# Altium schematic sheet iRTT-AU.SchDoc(iRTT-AU)
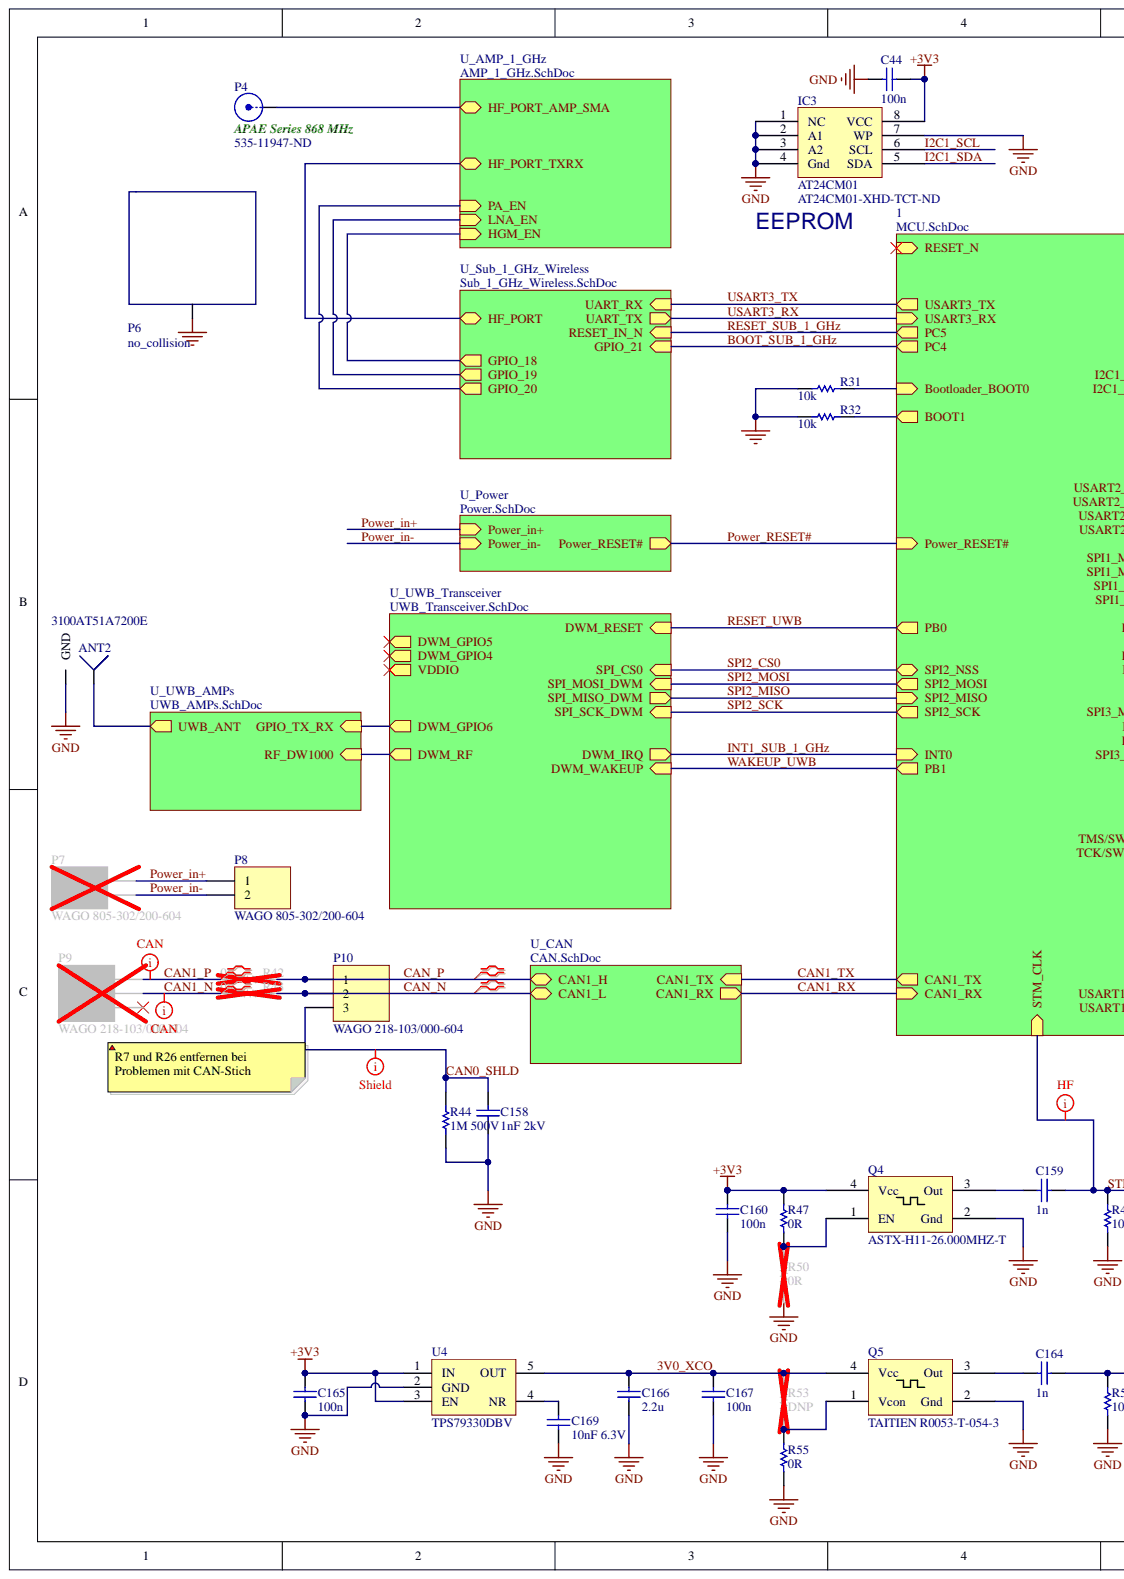
[diagram p0: iRTT-AU.SchDoc, left]
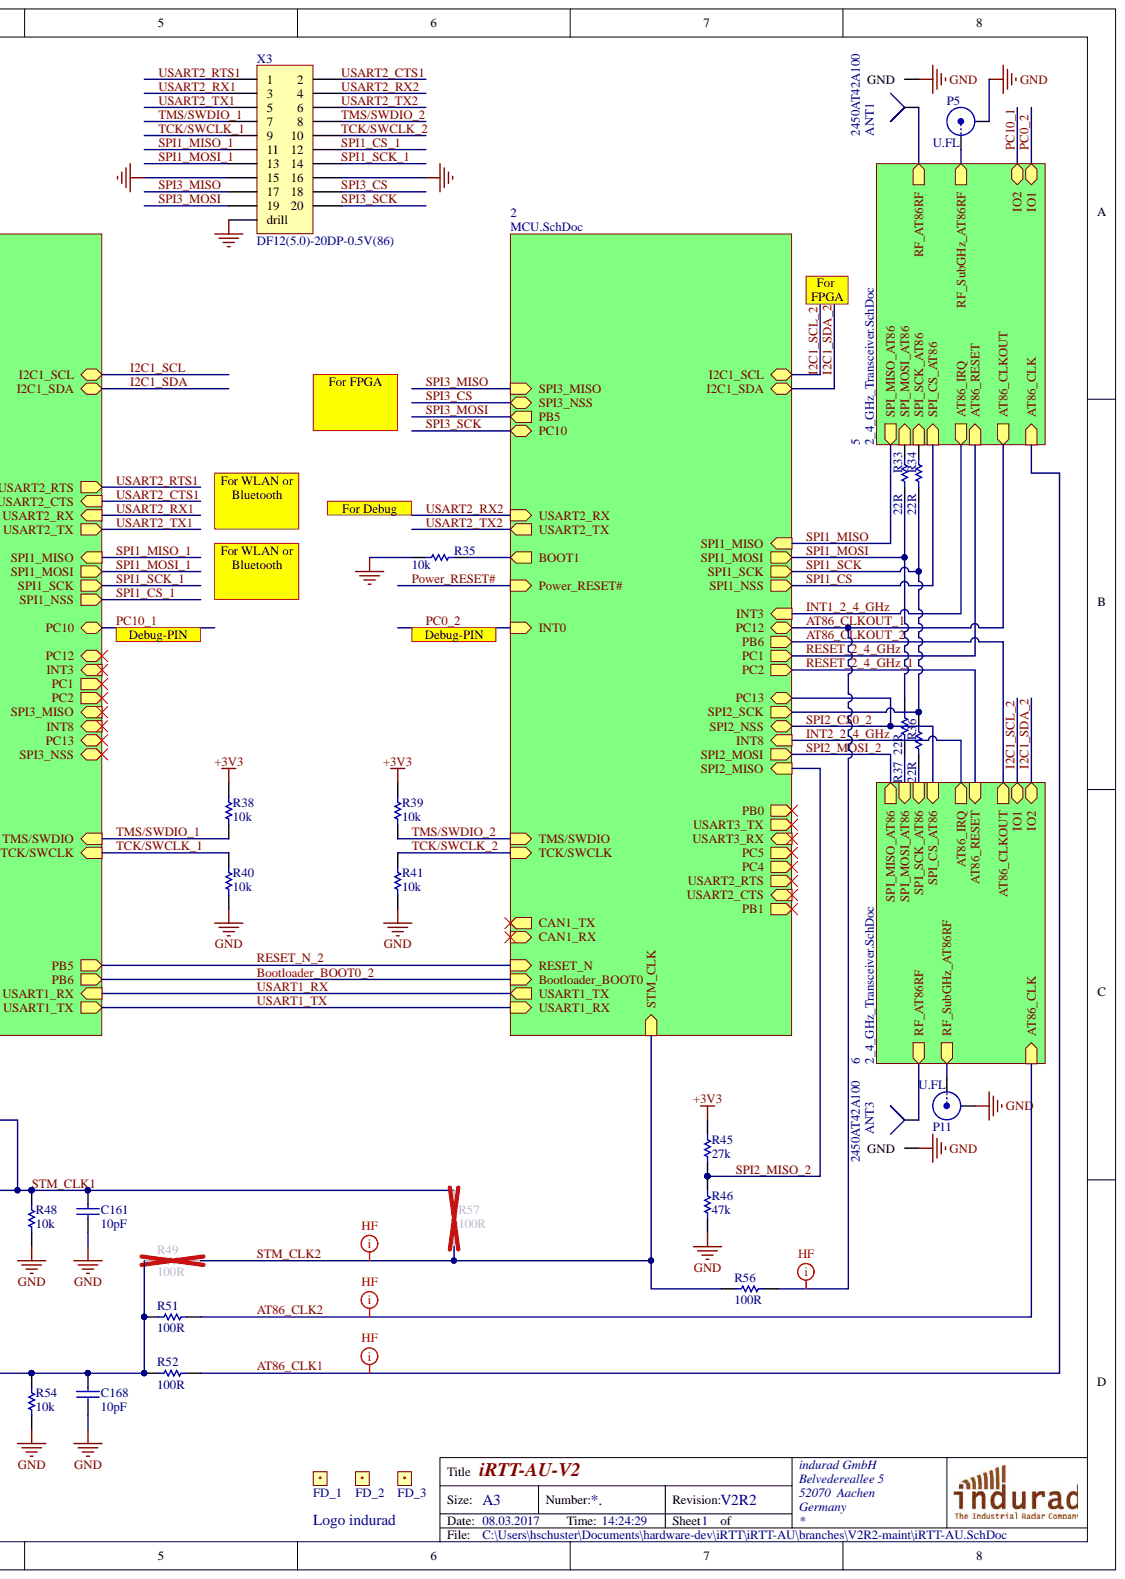
[diagram p1: iRTT-AU.SchDoc, right]

## Parts
ANT1  2450AT42A100  [2.4GHz_Antenna_2450AT42A100]
ANT2  3100AT51A7200E  [UWB_Antenne_3100AT51A7200E]
ANT3  2450AT42A100  [2.4GHz_Antenna_2450AT42A100]
C44  100n  [0402_N_(1005)]
C158  1nF 2kV  [CAPC_1206_N_(3216)]
C159  1n  [0402_N_(1005)]
C160  100n  [0402_N_(1005)]
C161  10pF  [0402_N_(1005)]
C164  1n  [0402_N_(1005)]
C165  100n  [0402_N_(1005)]
C166  2.2u  [0805_N_(2012)]
C167  100n  [0402_N_(1005)]
C168  10pF  [0402_N_(1005)]
C169  10nF 6.3V  Voltage - Rated: 6.3V  [0201_N_(0603)]
FD_1  Fiducial  [BestueckerMarke_ohne_TopOverlay]  (value not drawn)  (no BOM)
FD_2  Fiducial  [BestueckerMarke_ohne_TopOverlay]  (value not drawn)  (no BOM)
FD_3  Fiducial  [BestueckerMarke_ohne_TopOverlay]  (value not drawn)  (no BOM)
IC3  AT24CM01  Supplier Part Number 1: AT24CM01-XHD-TCT-ND  [TSSOP8]
logo1  Logo_indurad  [LOGO_INDURAD_H_3mm]  (no BOM)
P4  APAE Series 868 MHz  Supplier Part Number 1: 535-11947-ND  [APAE Series Abracon]
P5  U.FL  [U.FL Kupplung]
P6  ClassName: no_collision  [SMD Shielding Cabinet 29,96 X 19,10 mm]  (hidden comment: SMD Shield 29,36x18,50x2,54x0,20)
P7  WAGO 805-302/200-604  [WAGO 805-302/200-604]
P8  WAGO 805-302/200-604  [WAGO 805-302/200-604]
P9  WAGO 218-103/000-604  [WAGO 218-103/000-604]
P10  WAGO 218-103/000-604  [WAGO 218-103/000-604]
P11  U.FL  [U.FL Kupplung]
Q4  ASTX-H11-26.000MHZ-T  [Osz-3.2x2.5]
Q5  TAITIEN R0053-T-054-3  [Osz-3.2x2.5]
R31  10k  [0603_N_(1608)]
R32  10k  [0603_N_(1608)]
R33  22R  [0402_N_(1005)]
R34  22R  [0402_N_(1005)]
R35  10k  [0603_N_(1608)]
R36  22R  [0402_N_(1005)]
R37  22R  [0402_N_(1005)]
R38  10k  [0402_N_(1005)]
R39  10k  [0402_N_(1005)]
R40  10k  [0402_N_(1005)]
R41  10k  [0402_N_(1005)]
R42  0R  [0603_N_(1608)]
R43  0R  [0603_N_(1608)]
R44  1M 500V  [1210]
R45  27k  [0603_N_(1608)]
R46  47k  [0603_N_(1608)]
R47  0R  [0402_N_(1005)]
R48  10k  [0402_N_(1005)]
R49  100R  [0402_N_(1005)]
R50  0R  [0402_N_(1005)]
R51  100R  [0402_N_(1005)]
R52  100R  [0402_N_(1005)]
R53  DNP  [0402_N_(1005)]
R54  10k  [0402_N_(1005)]
R55  0R  [0402_N_(1005)]
R56  100R  [0402_N_(1005)]
R57  100R  [0402_N_(1005)]
U4  TPS79330DBV  [SOT23-5]
X3  DF12(5.0)-20DP-0.5V(86)  [Hirose DF12(5.0)-20DP-0.5V(86)]

## Nets
+3V3: C44.2 C160.2 C165.2 IC3.8(VCC) Q4.4(Vcc) R38.1 R39.1 R45.1 R47.2 U4.1(IN) U4.3(EN)
GND: ANT1.2 ANT2.2 ANT3.2 C44.1 C158.2 C160.1 C161.1 C165.1 C166.1 C167.1 C168.1 C169.1 IC3.1(NC) IC3.2(A1) IC3.3(A2) IC3.4(Gnd) IC3.7(WP) P5.2 P6.1 P11.2 Q4.2(Gnd) Q5.2(Gnd) R31.2 R32.2 R35.2 R40.2 R41.2 R44.1 R46.2 R48.2 R50.1 R54.2 R55.1 U4.2(GND) X3.15 X3.16 X3.21(drill)
3V0_XCO: C166.2 C167.2 Q5.4(Vcc) R53.2 U4.5(OUT)
AT86_CLK1: R52.1
AT86_CLK2: R51.1
AT86_CLKOUT_1: R56.1
CAN0_SHLD: C158.1 P10.3 R44.2
CAN1_N: P9.2 R43.2
CAN1_P: P9.3 R42.2
CAN_N: P10.2 R43.1
CAN_P: P10.1 R42.1
I2C1_SCL: IC3.6(SCL)
I2C1_SDA: IC3.5(SDA)
Power_in+: P7.2 P8.1
Power_in-: P7.1 P8.2
SPI1_CS_1: X3.12
SPI1_MISO_1: X3.11
SPI1_MOSI: R33.1 R37.2
SPI1_MOSI_1: X3.13
SPI1_SCK: R34.1 R36.2
SPI1_SCK_1: X3.14
SPI2_MISO_2: R45.2 R46.1
SPI3_CS: X3.18
SPI3_MISO: X3.17
SPI3_MOSI: X3.19
SPI3_SCK: X3.20
STM_CLK1: C159.2 C161.2 R48.1 R57.1
STM_CLK2: R49.1 R56.2 R57.2
TCK/SWCLK_1: R40.1 X3.9
TCK/SWCLK_2: R41.1 X3.10
TMS/SWDIO_1: R38.2 X3.7
TMS/SWDIO_2: R39.2 X3.8
USART2_CTS1: X3.2
USART2_RTS1: X3.1
USART2_RX1: X3.3
USART2_RX2: X3.4
USART2_TX1: X3.5
USART2_TX2: X3.6
NetANT1_1: ANT1.1
NetANT2_1: ANT2.1
NetANT3_1: ANT3.1
NetC159_1: C159.1 Q4.3(Out)
NetC164_1: C164.1 Q5.3(Out)
NetC164_2: C164.2 C168.2 R49.2 R51.2 R52.2 R54.1
NetC169_2: C169.2 U4.4(NR)
NetP4_1: P4.1
NetP5_1: P5.1
NetP9_1: P9.1
NetP11_1: P11.1
NetQ4_1: Q4.1(EN) R47.1 R50.2
NetQ5_1: Q5.1(Vcon) R53.1 R55.2
NetR31_1: R31.1
NetR32_1: R32.1
NetR33_2: R33.2
NetR34_2: R34.2
NetR35_1: R35.1
NetR36_1: R36.1
NetR37_1: R37.1
AT86_CLKOUT_2:
BOOT_SUB_1_GHz:
Bootloader_BOOT0_2:
CAN1_RX:
CAN1_TX:
I2C1_SCL_2:
I2C1_SDA_2:
INT1_2_4_GHz:
INT1_SUB_1_GHz:
INT2_2_4_GHz:
N00141:
N00142:
N00143:
N00144:
N00145:
N00146:
N00147:
N00148:
N00149:
N00150:
N00151:
N00152:
N00153:
N00154:
N00155:
N00156:
N00157:
N00158:
N00159:
N00160:
N00161:
N00162:
N00163:
N00164:
N00165:
N00166:
N00167:
N00168:
PC0_2:
PC10_1:
Power_RESET#:
RESET_2_4_GHz:
RESET_2_4_GHz_1:
RESET_N_2:
RESET_SUB_1_GHz:
RESET_UWB:
SPI1_CS:
SPI1_MISO:
SPI2_CS0:
SPI2_CS0_2:
SPI2_MISO:
SPI2_MOSI:
SPI2_MOSI_2:
SPI2_SCK:
USART1_RX:
USART1_TX:
USART3_RX:
USART3_TX:
WAKEUP_UWB:

## Library data of the parts
ANT1 ANT3 = 2.4 GHz Antenna: ANTENNA CHIP 2.4 - 2.5 GHZ; Manufacturer Part Number: 2450AT42A100E; Manufacturer: Johanson Technology Inc.; Return Loss: -9.5dB; Power - Max: 3W; Number of Bands: 1; Gain: 0dBi; Frequency Range: 2.4GHz ~ 2.5GHz; Frequency Group: UHF (2 GHz ~ 3 GHz); Frequency (Center/Band): 2.4GHz; VSWR: -; Termination: Solder; Supplier Part Number 1: 712-1007-1-ND; Supplier 1: Digi-Key; Stock 1: 32278; RoHS: RoHS Compliant; Pricing 1: 1=0.72, 10=0.633, 25=0.5604, 100=0.4881, 250=0.42484, 500=0.36158, 1000=0.28926 (EUR); Part Status: Active; Packaging: Cut Tape (CT); Mounting Type: Surface Mount; Ingress Protection: -; Height (Max): 0.043" (1.10mm); Features: -; ComponentLink1URL: http://www.johansontechnology.com/datasheets/antennas/2450AT42A100_v2.pdf; ComponentLink1Description: http://www.johansontechnology.com/datasheets/antennas/2450AT42A100_v2.pdf; Component Kind: Standard; Category: RF/IF and RFID; Applications: -; Antenna Type: Chip; Library Name: Indurad.SchLib
ANT2 = UWB Antenna: ANTENNA CHIP UWB 3.1 - 10.3 GHZ; Manufacturer: Johanson Technology Inc.; Return Loss: -9.5dB; Power - Max: 3W; Number of Bands: 1; Gain: 1.5dBi; Frequency Range: 3.1GHz ~ 10.3GHz; Frequency Group: SHF (3 GHz ~ 4 GHz), SHF (f > 4 GHz); Frequency (Center/Band): 6.7GHz; VSWR: -; Termination: Solder; Supplier Part Number 1: 712-1402-1-ND; Supplier 1: Digi-Key; Stock 1: 2506; RoHS: RoHS Compliant; Pricing 1: 1=2.49, 10=2.065, 25=1.9744, 50=1.9136, 100=1.7313, 250=1.4276, 500=1.2757 (EUR); Mounting Type: Surface Mount; Manufacturer Part Number: 3100AT51A7200E; Ingress Protection: -; Height (Max): 0.047" (1.20mm); Features: -; ComponentLink1URL: http://www.johansontechnology.com/datasheets/antennas/antenna_3100AT51A7200.pdf; ComponentLink1Description: http://www.johansontechnology.com/datasheets/antennas/antenna_3100AT51A7200.pdf; Component Kind: Standard; Category: RF/IF and RFID; Applications: -; Antenna Type: Chip; Library Name: Indurad.SchLib
C44 = CMP-1034-02072-1: CAP 100nF 25V ±10% 0402 (1005 Metric) Thickness 0.6mm SMD; Case-Metric: 1005; Case-EIA: 0402; Value: 100nF; Tolerance: ±10%; Technology: SMT; Rated Voltage: 25 V; Max Thickness: 0.6 mm; Library Name: Altium Vault; Component Kind: Standard
C158 = Cap: Capacitor; Value: 1nF 2kV; Simulation: CAP; Signal Integrity: Cap; Published: 8-Jun-2000; LatestRevisionNote: Aufgenommen; LatestRevisionDate: 18.10.2012; Component Kind: Standard; Publisher: Altium Limited; Library Name: Indurad.SchLib
C159 C164 = Cap: Capacitor; Manufacturer Part Number: GRM155R71H102KA01D; Manufacturer: Murata Electronics North America; Voltage - Rated: 50V; Tolerance: ±10%; Temperature Coefficient: X7R; Capacitance: 1000pF; Value: 1n; Thickness (Max): 0.022" (0.55mm); Supplier Part Number 1: 490-1303-1-ND; Supplier 1: Digi-Key; Stock 1: 5002515; Size / Dimension: 0.039" L x 0.020" W (1.00mm x 0.50mm); Simulation: CAP; Signal Integrity: Cap; RoHS: RoHS Compliant; Ratings: -; Pricing 1: 1=0.16, 10=0.016, 50=0.0094, 100=0.0078, 250=0.00636, 500=0.00544, 1000=0.00422, 5000=0.00353 (EUR); Part Status: Active; Packaging: Cut Tape (CT); Package / Case: 0402 (1005 Metric); Operating Temperature: -55°C ~ 125°C; Mounting Type: Surface Mount, MLCC; Lead Style: -; Lead Spacing: -; LatestRevisionNote: Aufgenommen; LatestRevisionDate: 20130712; Height - Seated (Max): -; Features: -; ComponentLink1URL: http://www.murata.com/~/media/webrenewal/support/library/catalog/products/capacitor/mlcc/c02e.pdf; ComponentLink1Description: http://www.murata.com/~/media/webrenewal/support/library/catalog/products/capacitor/mlcc/c02e.pdf; Component Kind: Standard; Category: Capacitors; Applications: General Purpose; Publisher: Indurad; Library Name: Indurad.SchLib
C160 C165 C167 = Cap: Capacitor; Manufacturer Part Number: GRM155R70J104KA01D; Manufacturer: Murata Electronics North America; Voltage - Rated: 6.3V; Tolerance: ±10%; Temperature Coefficient: X7R; Capacitance: 0.1µF; Value: 100n; Thickness (Max): 0.022" (0.55mm); Supplier Part Number 1: 490-6319-1-ND; Supplier 1: Digi-Key; Stock 1: 430852; Size / Dimension: 0.039" L x 0.020" W (1.00mm x 0.50mm); Simulation: CAP; Signal Integrity: Cap; RoHS: RoHS Compliant; Ratings: -; Pricing 1: 1=0.1, 10=0.025, 50=0.0136, 100=0.0114, 250=0.00936, 500=0.008, 1000=0.00622, 5000=0.00519 (EUR); Packaging: Cut Tape (CT); Package / Case: 0402 (1005 Metric); Operating Temperature: -55°C ~ 125°C; Mounting Type: Surface Mount, MLCC; Lead Spacing: -; LatestRevisionNote: Aufgenommen; LatestRevisionDate: 20130712; Height - Seated (Max): -; Features: -; ComponentLink1URL: http://www.murata.com/~/media/webrenewal/support/library/catalog/products/capacitor/mlcc/c02e.ashx; ComponentLink1Description: http://www.murata.com/~/media/webrenewal/support/library/catalog/products/capacitor/mlcc/c02e.ashx; Component Kind: Standard; Category: Capacitors; Applications: General Purpose; Publisher: Indurad; Library Name: Indurad.SchLib
C161 C168 = Cap: Capacitor; Manufacturer Part Number: GRM1555C1H120JA01D; Manufacturer: Murata Electronics North America; Voltage - Rated: 50V; Tolerance: ±5%; Temperature Coefficient: C0G, NP0; Capacitance: 12pF; Value: 10pF; Thickness (Max): 0.022" (0.55mm); Supplier Part Number 1: 490-5924-1-ND; Supplier 1: Digi-Key; Stock 1: 400625; Size / Dimension: 0.039" L x 0.020" W (1.00mm x 0.50mm); Simulation: CAP; Signal Integrity: Cap; RoHS: RoHS Compliant; Ratings: -; Pricing 1: 1=0.14, 10=0.019, 50=0.0106, 100=0.0091, 250=0.0074, 500=0.00636, 1000=0.00494, 5000=0.00412 (EUR); Packaging: Cut Tape (CT); Package / Case: 0402 (1005 Metric); Operating Temperature: -55°C ~ 125°C; Mounting Type: Surface Mount, MLCC; Lead Style: -; Lead Spacing: -; LatestRevisionNote: Aufgenommen; LatestRevisionDate: 20130712; Height - Seated (Max): -; Features: -; ComponentLink1URL: http://www.murata.com/~/media/webrenewal/support/library/catalog/products/capacitor/mlcc/c02e.pdf; ComponentLink1Description: http://www.murata.com/~/media/webrenewal/support/library/catalog/products/capacitor/mlcc/c02e.pdf; Component Kind: Standard; Category: Capacitors; Applications: General Purpose; Publisher: Indurad; Library Name: Indurad.SchLib
C166 = Cap: Capacitor; Manufacturer Part Number: C2012X7R1C225K125AB; Manufacturer: TDK Corporation; Voltage - Rated: 16V; Tolerance: ±10%; Temperature Coefficient: X7R; Capacitance: 2.2µF; Value: 2.2u; Thickness (Max): 0.057" (1.45mm); Supplier Part Number 1: 445-1420-1-ND; Supplier 1: Digi-Key; Stock 1: 164691; Size / Dimension: 0.079" L x 0.049" W (2.00mm x 1.25mm); Simulation: CAP; Signal Integrity: Cap; RoHS: RoHS Compliant; Ratings: -; Pricing 1: 1=0.17, 10=0.119, 100=0.0604, 250=0.04892, 500=0.04318, 1000=0.0367 (EUR); Part Status: Active; Packaging: Cut Tape (CT); Package / Case: 0805 (2012 Metric); Operating Temperature: -55°C ~ 125°C; Mounting Type: Surface Mount, MLCC; Lead Style: -; Lead Spacing: -; LatestRevisionNote: Aufgenommen; LatestRevisionDate: 20130712; Height - Seated (Max): -; Features: Low ESL; Failure Rate: -; ComponentLink1URL: https://product.tdk.com/info/en/catalog/datasheets/mlcc_commercial_general_en.pdf; ComponentLink1Description: https://product.tdk.com/info/en/catalog/datasheets/mlcc_commercial_general_en.pdf; Component Kind: Standard; Category: Capacitors; Applications: General Purpose; Publisher: Indurad; Library Name: Indurad.SchLib
C169 = Cap: Capacitor; Manufacturer Part Number: GRM033R70J103KA01D; Manufacturer: Murata Electronics North America; Tolerance: ±10%; Temperature Coefficient: X7R; Capacitance: 10000pF; Value: 10nF 6.3V; Thickness (Max): 0.013" (0.33mm); Supplier Part Number 1: 490-1262-1-ND; Supplier 1: Digi-Key; Stock 1: 506964; Size / Dimension: 0.024" L x 0.012" W (0.60mm x 0.30mm); Simulation: CAP; Signal Integrity: Cap; RoHS: RoHS Compliant; Ratings: -; Pricing 1: 1=0.09, 10=0.021, 50=0.0112, 100=0.0095, 250=0.00772, 500=0.0066, 1000=0.00514, 5000=0.00428 (EUR); Packaging: Cut Tape (CT); Package / Case: 0201 (0603 Metric); Operating Temperature: -55°C ~ 125°C; Mounting Type: Surface Mount, MLCC; Lead Style: -; Lead Spacing: -; LatestRevisionNote: Aufgenommen; LatestRevisionDate: 20130712; Height - Seated (Max): -; Features: -; ComponentLink1URL: http://www.murata.com/~/media/webrenewal/support/library/catalog/products/capacitor/mlcc/c02e.pdf; ComponentLink1Description: http://www.murata.com/~/media/webrenewal/support/library/catalog/products/capacitor/mlcc/c02e.pdf; Component Kind: Standard; Category: Capacitors; Applications: General Purpose; Publisher: Indurad; Library Name: Indurad.SchLib
IC3 = AT24CM01: EEPROM 1024k 2,5 V bis 5,5 V 400kBit/s / 1MBit/s; Manufacturer Part Number: AT24CM01-XHD-T; Manufacturer: Atmel; Voltage - Supply: 2.5 V ~ 5.5 V; Speed: 1MHz; Memory Size: 1M (128K x 8); Interface: I²C, 2-Wire Serial; Format - Memory: EEPROMs - Serial; Supplier Device Package: 8-TSSOP; Supplier 1: Digi-Key; Stock 1: 6550; RoHS: RoHS Compliant; Pricing 1: 1=2.94, 25=2.4764, 100=2.0077, 1000=1.84707 (EUR); Packaging: Cut Tape (CT); Package / Case: 8-TSSOP (0.173", 4.40mm Width); Operating Temperature: -40°C ~ 85°C; Memory Type: EEPROM; ComponentLink1URL: http://www.atmel.com/Images/Atmel-8812-SEEPROM-AT24CM01-Datasheet.pdf; ComponentLink1Description: http://www.atmel.com/Images/Atmel-8812-SEEPROM-AT24CM01-Datasheet.pdf; Component Kind: Standard; Category: Integrated Circuits (ICs); Library Name: Indurad.SchLib
logo1 = Logo_indurad: Logo von indurad GmbH; Library Name: Indurad.SchLib
P4 = APAE Series: 24 X 24 mm Ceramic Antenna; Manufacturer Part Number: APAE868R2540JBDB2-T; Manufacturer: Abracon LLC; VSWR: 1.5; Number of Bands: 1; Gain: 4.5dBic; Frequency Range: 867MHz ~ 869MHz; Frequency Group: UHF (300 MHz ~ 1 GHz); Frequency (Center/Band): 868MHz; Antenna Type: Ceramic Patch; Termination: Pin; Supplier 1: Digi-Key; Stock 1: 636; RoHS: RoHS Compliant; Return Loss: -; Pricing 1: 1=2.64, 10=2.356, 25=2.1208, 100=1.932, 250=1.74356, 500=1.56448, 750=1.41368, 1000=1.31944 (EUR); Power - Max: -; Mounting Type: Adhesive; Ingress Protection: -; Height (Max): 0.157" (4.00mm); Features: -; ComponentLink1URL: http://www.abracon.com/Support/PackageDrawing/patch-antenna/APAE868R2540JBDB2-T.PDF; ComponentLink1Description: http://www.abracon.com/Support/PackageDrawing/patch-antenna/APAE868R2540JBDB2-T.PDF; Component Kind: Standard; Category: RF/IF and RFID; Applications: GPS; Library Name: Indurad.SchLib
P5 P11 = U.FL KUPPLUNG: Manufacturer Part Number: U.FL-R-SMT-1(10); Manufacturer: HRS (HIROSE); Supplier Part Number 1: 1688077; Supplier 1: Farnell; Stock 1: 104388; RoHS: YES; Pricing 1: 1=0,481, 10=0,436, 25=0,407, 50=0,365, 100=0,33 (EUR); LatestRevisionNote: Re-released for DXP Platform.; LatestRevisionDate: 17-Jul-2002; Description: U.FL KUPPLUNG; ComponentLink1URL: http://www.farnell.com/datasheets/91524.pdf; ComponentLink1Description: http://www.farnell.com/datasheets/91524.pdf; Component Kind: Standard; Publisher: Altium Limited; Library Name: Indurad.SchLib
P6 = SMD Shield 29,36x18,50x2,54x0,20: SMD Shielding Cabinet SGH-29,36x18,50x2,54x0,20; Manufacturer Part Number: SGH-207953-F / SGH-29,96x19,10x2,00x0,15-FS-C; Manufacturer: MTC; Supplier Part Number 1: SGH-207953-F / SGH-29,96x19,10x2,00x0,15-FS-C; Supplier 1: MTC; Component Kind: Standard; Library Name: Indurad.SchLib
P7 P8 = WAGO 805-302/200-604: Header, 2-Pin, single row; Signal Integrity: Connector; Component Kind: Standard; Library Name: Indurad.SchLib
P9 P10 = WAGO 218-103/000-604: Header, 3-Pin, single row; Signal Integrity: Connector; Component Kind: Standard; Library Name: Indurad.SchLib
Q4 = ASTX-H11-26.000MHZ-T: OSC TCXO 26.000MHZ HCMOS SMD; Manufacturer: Abracon LLC; Voltage - Supply: 3.3V; Function: Enable/Disable; Frequency Stability: ±2.5ppm; Frequency: 26MHz; Current - Supply (Max): 5.5mA; Type: TCXO; Supplier Part Number 1: 535-12047-1-ND; Supplier 1: Digi-Key; Stock 1: 865; Size / Dimension: 0.126" L x 0.098" W (3.20mm x 2.50mm); RoHS: RoHS Compliant; Ratings: -; Pricing 1: 1=3.19, 10=3.04, 50=2.8878, 100=2.5838, 500=2.43184 (EUR); Part Status: Active; Packaging: Cut Tape (CT); Package / Case: 4-SMD, No Lead; Output: HCMOS; Operating Temperature: -30°C ~ 75°C; Mounting Type: Surface Mount; Manufacturer Part Number: ASTX-H11-26.000MHZ-T; Height - Seated (Max): 0.043" (1.10mm); Current - Supply (Disable) (Max): -; ComponentLink1URL: http://www.abracon.com/Oscillators/ASTX-H11.pdf; ComponentLink1Description: http://www.abracon.com/Oscillators/ASTX-H11.pdf; Component Kind: Standard; Category: Crystals, Oscillators, Resonators; Library Name: Indurad.SchLib
Q5 = TAITIEN R0053-T-054-3: Temperaturkompensierter Quarzoszillator, R0053-T-054-3 26 MHz 2,8 → 3,3 V, SMD 4-Pin 3.2x2.5mm; Supplier Part Number 1: 709-9420; Supplier 1: RS Components; Component Kind: Standard; Library Name: Indurad.SchLib
R31-R57 = Res: Resistor; Simulation: RESISTOR; Signal Integrity: Res; Published: 8-Jun-2000; LatestRevisionNote: Aufgenommen; LatestRevisionDate: 18.20.2012; Component Kind: Standard; Publisher: Altium Limited; Library Name: Indurad.SchLib
  R31 R32 R35 R38-R41 R48 R54: Value: 10k
  R33 R34 R36 R37: Value: 22R
  R42 R43 R47 R50 R55: Value: 0R
  R44: Value: 1M 500V; Supplier Part Number 1: 1750877; Supplier 1: Farnell
  R45: Value: 27k
  R46: Value: 47k
  R49 R51 R52 R56 R57: Value: 100R
  R53: Value: DNP
U4 = TPS79330: Ultralow-Noise, High PSRR, Fast RF 200mA LDO Linear Regulators (Rev. K); Supplier Part Number 1: 595-TPS79330DBVR; Supplier 1: Mouser; Component Kind: Standard; Library Name: Indurad.SchLib
X3 = Header 10X2 SMD 0.5mm: Header, 20-Pin, 0.5mm pitch; Manufacturer: Hirose Electric Co Ltd; Pitch: 0.020" (0.50mm); Number of Positions: 20; Mated Stacking Heights: 5mm; Connector Type: Header, Outer Shroud Contacts; Supplier Part Number 1: H5244CT-ND; Supplier 1: Digi-Key; Stock 1: 8035; RoHS: RoHS Compliant; Pricing 1: 1=0.84, 10=0.74, 25=0.6972, 50=0.668, 100=0.639, 250=0.58096, 500=0.52286 (EUR); Part Status: Active; Packaging: Cut Tape (CT); Number of Rows: 2; Mounting Type: Surface Mount; Manufacturer Part Number: DF12(5.0)-20DP-0.5V(86); Height Above Board: 0.169" (4.30mm); Features: Board Guide, Solder Retention; Contact Finish Thickness: 8µin (0.20µm); Contact Finish: Gold; ComponentLink1URL: https://www.hirose.com/product/en/download_file/key_name/DF12/category/Catalog/doc_file_id/31693/?file_category_id=4&item_id=23&is_series=1; ComponentLink1Description: https://www.hirose.com/product/en/download_file/key_name/DF12/category/Catalog/doc_file_id/31693/?file_category_id=4&item_id=23&is_series=1; Component Kind: Standard; Category: Connectors, Interconnects; Library Name: Indurad.SchLib
FD_1-FD_3 = Fiducial: Description: Fiducial; Library Name: Indurad.SchLib
